# Altium schematic sheet 555-indicator-sheet.SchDoc(555-indicator-sheet)
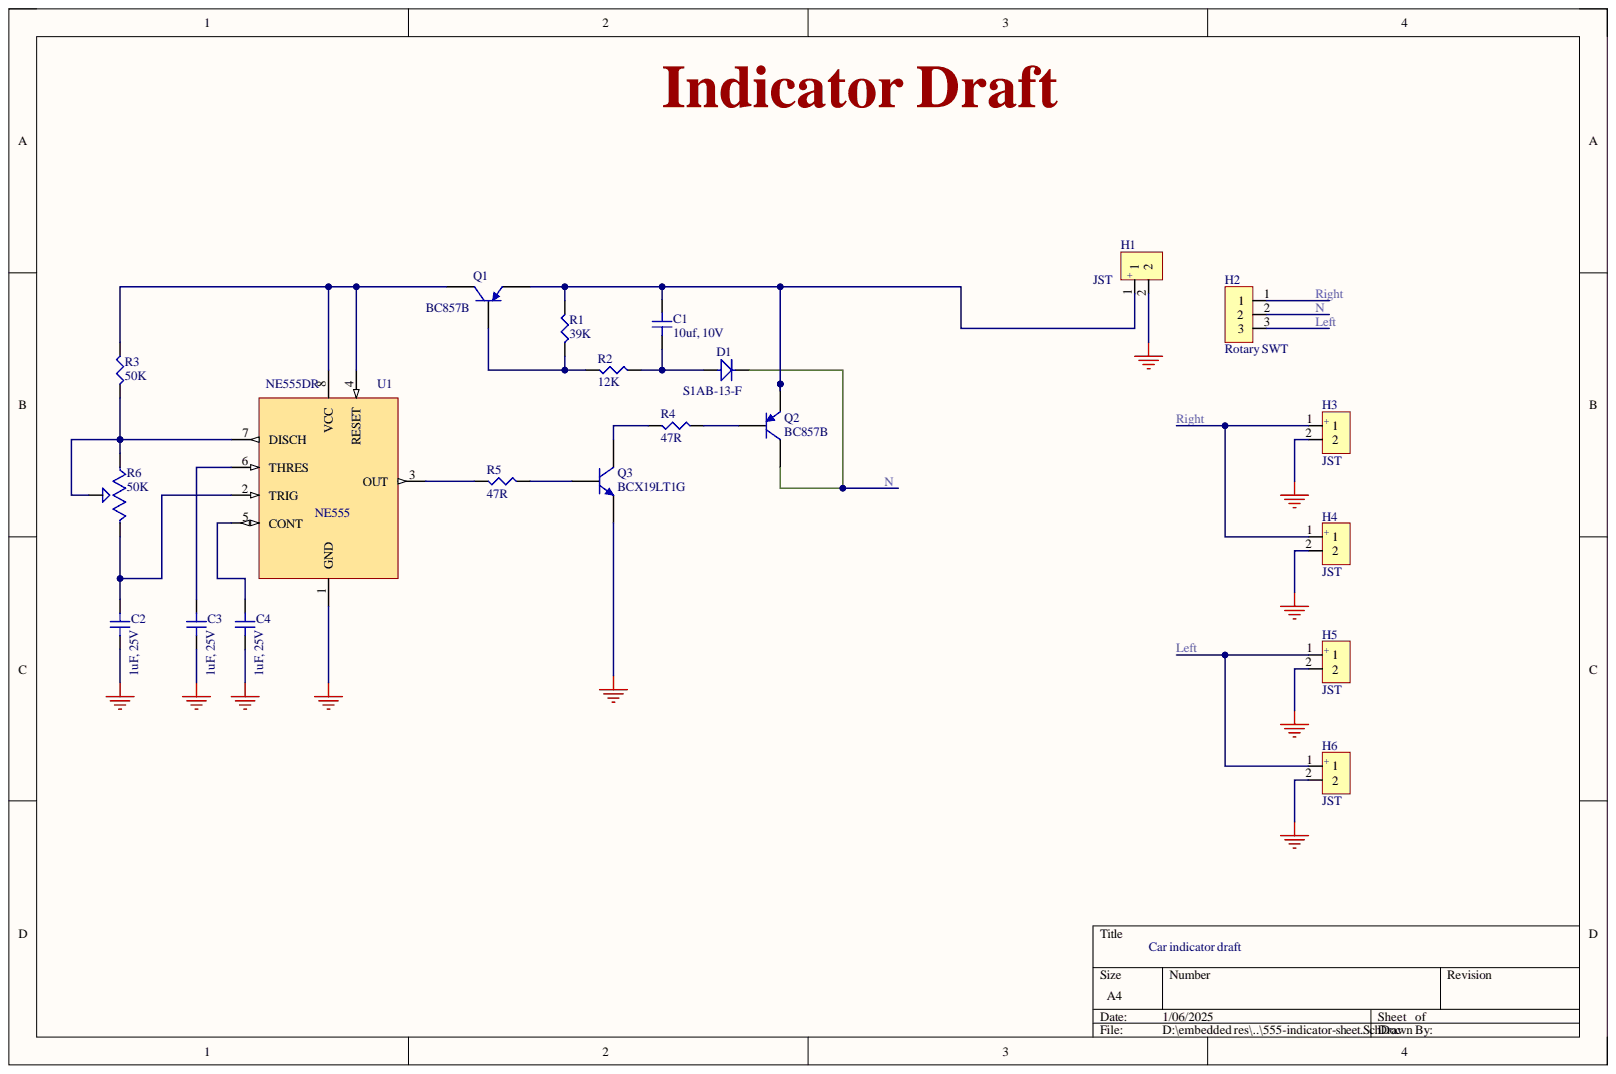
[diagram p0: 555-indicator-sheet.SchDoc]

## Parts
C1  10uf, 10V  [CAPC2012X140N]
C2  1uF, 25V  Rated DC Voltage (URdc): 25V  [CAPC2012X140N]
C3  1uF, 25V  Rated DC Voltage (URdc): 25V  [CAPC2012X140N]
C4  1uF, 25V  Rated DC Voltage (URdc): 25V  [CAPC2012X140N]
D1  S1AB-13-F  [FP-SMB-MFG]
H1  JST  [FP-B2B-XH-A_LF_SN-MFG]
H2  Rotary SWT  [JST_B3B-XH-A]
H3  JST  [FP-B2B-XH-A_LF_SN-MFG]
H4  JST  [FP-B2B-XH-A_LF_SN-MFG]
H5  JST  [FP-B2B-XH-A_LF_SN-MFG]
H6  JST  [FP-B2B-XH-A_LF_SN-MFG]
Q1  BC857B  [PNP_BC857B,235]
Q2  BC857B  [PNP_BC857B,235]
Q3  BCX19LT1G  [NPN_BCX19LT1G]
R1  39K  [RESC3116X65N]
R2  12K  [RESC3116X65N]
R3  50K  [RESC3116X65N]
R4  47R  [RESC3116X65N]
R5  47R  [RESC3116X65N]
R6  50K  [TRIM_3266W-1-503LF]
U1  NE555DR  [FP-D0008A-MFG]

## Nets
GND: C2.2 C3.2 C4.2 H1.2 H3.2 H4.2 H5.2 H6.2 Q3.2 U1.1(GND)
Left: H2.3 H5.1 H6.1
N: D1.2 H2.2 Q2.3
Right: H2.1 H3.1 H4.1
NetC1_1: C1.1 D1.1 R2.2
NetC1_2: C1.2 H1.1 Q1.2 Q2.2 R1.1
NetC2_1: C2.1 R6.3 U1.2(TRIG)
NetC3_1: C3.1 U1.6(THRES)
NetC4_1: C4.1 U1.5(CONT)
NetQ1_1: Q1.1 R1.2 R2.1
NetQ1_3: Q1.3 R3.1 U1.4(RESET) U1.8(VCC)
NetQ2_1: Q2.1 R4.2
NetQ3_1: Q3.1 R5.2
NetQ3_3: Q3.3 R4.1
NetR3_2: R3.2 R6.1 R6.2 U1.7(DISCH)
NetR5_1: R5.1 U1.3(OUT)

## Library data of the parts
C1 = CL21A106KPFNNNE: CAP CER 10UF 10V X5R 0805; Manufacturer: Samsung; Capacitor Type: Ceramic; Multilayer: Yes; Tolerance  (fill in as +-): 10%; Case/Package: 0805; Category: Ceramic Capacitors; Component Kind: Standard; ComponentLink1Description: https://datasheet.ciiva.com:443/pdfs/VipMasterIC/IC/SEMS/SEMS-S-A0008526420/SEMS-S-A0008526398-1.pdf?src-supplier=IHS+Markit; ComponentLink1URL: https://datasheet.ciiva.com:443/pdfs/VipMasterIC/IC/SEMS/SEMS-S-A0008526420/SEMS-S-A0008526398-1.pdf?src-supplier=IHS+Markit; ComponentLink2Description: https://datasheet.ciiva.com/16199/cl05b104kq5nnnc-16199024.pdf?src-supplier=Future+Electronics; ComponentLink2URL: https://datasheet.ciiva.com/16199/cl05b104kq5nnnc-16199024.pdf?src-supplier=Future+Electronics; ComponentLink3Description: https://datasheet.ciiva.com/15195/getdatasheetpartid-357423-15195857.pdf?src-supplier=Verical; ComponentLink3URL: https://datasheet.ciiva.com/15195/getdatasheetpartid-357423-15195857.pdf?src-supplier=Verical; ComponentLink4Description: https://datasheet.octopart.com/CL21A106KPFNNNE-Samsung-Electro-Mechanics-datasheet-136031801.pdf?src-supplier=Farnell; ComponentLink4URL: https://datasheet.octopart.com/CL21A106KPFNNNE-Samsung-Electro-Mechanics-datasheet-136031801.pdf?src-supplier=Farnell; ComponentLink5Description: https://datasheet.octopart.com/CL21A106KPFNNNE-Samsung-Electro-Mechanics-datasheet-8324245.pdf; ComponentLink5URL: https://datasheet.octopart.com/CL21A106KPFNNNE-Samsung-Electro-Mechanics-datasheet-8324245.pdf; ComponentLink6Description: https://datasheet.octopart.com/CL21A106KPFNNNE-Samsung-Electro-Mechanics-datasheet-97040617.pdf; ComponentLink6URL: https://datasheet.octopart.com/CL21A106KPFNNNE-Samsung-Electro-Mechanics-datasheet-97040617.pdf; ComponentLink7Description: https://datasheet.octopart.com/General-Multilayer-Ceramic-Capacitors-Samsung-datasheet-family-111.pdf; ComponentLink7URL: https://datasheet.octopart.com/General-Multilayer-Ceramic-Capacitors-Samsung-datasheet-family-111.pdf; ComponentLink8Description: https://datasheet.octopart.com/CL21A106KPFNNNE-Samsung-Electro-Mechanics-datasheet-110326887.pdf?src-supplier=Future+Electronics; ComponentLink8URL: https://datasheet.octopart.com/CL21A106KPFNNNE-Samsung-Electro-Mechanics-datasheet-110326887.pdf?src-supplier=Future+Electronics; ComponentLink9Description: https://datasheet.octopart.com/CL21A106KPFNNNE-Samsung-Electro-Mechanics-datasheet-81462124.pdf?src-supplier=Future+Electronics; ComponentLink9URL: https://datasheet.octopart.com/CL21A106KPFNNNE-Samsung-Electro-Mechanics-datasheet-81462124.pdf?src-supplier=Future+Electronics; ComponentLink10Description: https://datasheet.octopart.com/CL21A106KPFNNNE-Samsung-datasheet-48647544.pdf?src-supplier=Future+Electronics; ComponentLink10URL: https://datasheet.octopart.com/CL21A106KPFNNNE-Samsung-datasheet-48647544.pdf?src-supplier=Future+Electronics; ComponentLink11Description: https://datasheet.octopart.com/CL21A106KPFNNNE-Samsung-datasheet-26669229.pdf?src-supplier=Future+Electronics; ComponentLink11URL: https://datasheet.octopart.com/CL21A106KPFNNNE-Samsung-datasheet-26669229.pdf?src-supplier=Future+Electronics; ComponentLink12Description: https://datasheet.octopart.com/CL21A106KPFNNNE-Samsung-datasheet-22147434.pdf?src-supplier=TME; ComponentLink12URL: https://datasheet.octopart.com/CL21A106KPFNNNE-Samsung-datasheet-22147434.pdf?src-supplier=TME; ComponentLink13Description: https://datasheet.octopart.com/CL21A106KPFNNNE-Samsung-Electro-Mechanics-datasheet-11781485.pdf?src-supplier=Arrow+Electronics; ComponentLink13URL: https://datasheet.octopart.com/CL21A106KPFNNNE-Samsung-Electro-Mechanics-datasheet-11781485.pdf?src-supplier=Arrow+Electronics; Dielectric Material: Ceramic; Height: 1.35mm; JESD-609 Code: e3; LCSC part no: C17024; Length: 2mm; Manufacturer Part Number: CL21A106KPFNNNE; Max Operating Temperature: 85°C; Min Operating Temperature: -55°C; Mounting Technology: Surface Mount; Package Shape: Rectangular; Package Style: SMTMeter; Packaging: Tape and Reel; Pins: 2; Pricing: 1=0.1, 10=0.054, 50=0.0374, 100=0.0322, 500=0.02334, 1000=0.0206 (USD); Rated DC Voltage (URdc): 10V; Reach SVHC Compliant: Yes; RoHS Compliant: Yes; Stock: 539282; Supplier: Digikey; Supplier Part Number: 1276-1052-6-ND; Temperature Characteristics Code: X5R; Terminal Finish: Nickel; Termination: Wraparound; Tolerance (fill in as +-): 10%; Value: 10uF; Width: 1.25mm; Library Name: 555-indicator-Schlib.SchLib
C2-C4 = CL21B105KAFNNNE: CAP CER 1UF 25V X7R 0805; Manufacturer: Samsung; Capacitor Type: Ceramic; Multilayer: Yes; Tolerance  (fill in as +-): 10%; Case/Package: 0805; Category: Ceramic Capacitors; Component Kind: Standard; ComponentLink1Description: https://datasheet.ciiva.com:443/pdfs/VipMasterIC/IC/SEMS/SEMS-S-A0008523501/SEMS-S-A0008523563-1.pdf?src-supplier=IHS+Markit; ComponentLink1URL: https://datasheet.ciiva.com:443/pdfs/VipMasterIC/IC/SEMS/SEMS-S-A0008523501/SEMS-S-A0008523563-1.pdf?src-supplier=IHS+Markit; ComponentLink2Description: https://datasheet.ciiva.com/25800/cl21b105kafnnne-25800163.pdf?src-supplier=Digikey; ComponentLink2URL: https://datasheet.ciiva.com/25800/cl21b105kafnnne-25800163.pdf?src-supplier=Digikey; ComponentLink3Description: https://datasheet.ciiva.com/15195/getdatasheetpartid-355205-15195880.pdf?src-supplier=Verical; ComponentLink3URL: https://datasheet.ciiva.com/15195/getdatasheetpartid-355205-15195880.pdf?src-supplier=Verical; Dielectric Material: Ceramic; Height: 1.35mm; JESD-609 Code: e3; LCSC part no: C116352; Length: 2mm; Manufacturer Part Number: CL21B105KAFNNNE; Max Operating Temperature: 125°C; Min Operating Temperature: -55°C; Mounting Technology: Surface Mount; Package Shape: Rectangular; Package Style: SMTMeter; Packaging: Tape and Reel; Pins: 2; Pricing: 1=0.08, 10=0.027, 50=0.022, 100=0.0168, 500=0.0152, 1000=0.0136 (USD); Reach SVHC Compliant: Yes; RoHS: Yes; RoHS Compliant: Yes; Stock: 1459194; Supplier: Digikey; Supplier Part Number: 1276-1066-6-ND; Temperature Characteristics Code: X7R; Terminal Finish: Nickel; Termination: Wraparound; Tolerance (fill in as +-): 10%; Value: 1uF; Width: 1.25mm; Library Name: 555-indicator-Schlib.SchLib
D1 = S1AB-13-F: DIODE GEN PURP 50V 1A DO214AC; Manufacturer: Diodes; Additional Feature: HIGH RELIABILITY; Diode Element Material: SILICON; Diode Type: RECTIFIER; Forward Voltage: 1.1V; Non-rep Pk Forward Current-Max: 30A; Number of Elements: 1; Package Body Material: Plastic; Qualification Status: Not Qualified; Reverse Recovery Time-Max: 3us; Time @ Peak Reflow Temperature-Max (s): 40s; Category: Rectifier Diodes; Component Kind: Standard; ComponentLink1Description: https://datasheet.ciiva.com:443/pdfs/VipMasterIC/IC/DIOD/DIOD-S-A0007810797/DIOD-S-A0007810797-1.pdf?src-supplier=IHS+Markit; ComponentLink1URL: https://datasheet.ciiva.com:443/pdfs/VipMasterIC/IC/DIOD/DIOD-S-A0007810797/DIOD-S-A0007810797-1.pdf?src-supplier=IHS+Markit; JESD-30 Code: R-PDSO-C2; JESD-609 Code: e3; LCSC part no: C519094; Manufacturer Part Number: S1AB-13-F; Max Operating Temperature: 150°C; Max Output current: 1A; Min Operating Temperature: -65°C; Moisture Sensitivity Level: 1; Package Shape: Rectangular; Package Style: SMALL OUTLINEMeter; Peak Reflow Temperature (Cel): 260°C; Pins: 2; Pricing: 1=0.18, 10=0.121, 100=0.0813, 500=0.06208, 1000=0.05559 (USD); Reach SVHC Compliant: No; Rep Pk Reverse Voltage-Max: 50V; RoHS: Yes; RoHS Compliant: Yes; Stock: 182225; Supplier: Digikey; Supplier Part Number: S1AB-FDICT-ND; Surface Mount: Yes; Terminal Finish: Tin; Terminal Form: C BEND; Terminal Position: DUAL; Library Name: 555-indicator-Schlib.SchLib
H1 H3-H6 = B2B-XH-A(LF)(SN): CONN HEADER VERT 2POS 2.5MM; Category: Headers and Wire Housings; Component Kind: Standard; ComponentLink1Description: https://datasheet.ciiva.com/11746/0900766b8082653e-11746979.pdf?src-supplier=Rs; ComponentLink1URL: https://datasheet.ciiva.com/11746/0900766b8082653e-11746979.pdf?src-supplier=Rs; ComponentLink2Description: https://datasheet.ciiva.com/26894/getdatasheetpartid-640357-26894434.pdf?src-supplier=Verical; ComponentLink2URL: https://datasheet.ciiva.com/26894/getdatasheetpartid-640357-26894434.pdf?src-supplier=Verical; ComponentLink3Description: https://datasheet.ciiva.com/26894/getdatasheetpartid-385291-26894760.pdf?src-supplier=Verical; ComponentLink3URL: https://datasheet.ciiva.com/26894/getdatasheetpartid-385291-26894760.pdf?src-supplier=Verical; ComponentLink4Description: https://datasheet.ciiva.com/8052/5448-8052024.pdf?src-supplier=Element14; ComponentLink4URL: https://datasheet.ciiva.com/8052/5448-8052024.pdf?src-supplier=Element14; LCSC part no: C158012; Manufacturer: JST; Manufacturer Part Number: B2B-XH-A(LF)(SN); Pricing: 1=0.0563, 750=0.0541, 2500=0.0518, 7500=0.0498, 25000=0.0458 (USD); RoHS: Yes; Stock: 668373; Supplier: Future Electronics; Supplier Part Number: 1638840; Library Name: 555-indicator-Schlib.SchLib
H2 = B3B-XH-A(LF)(SN): CONN HEADER VERT 3POS 2.5MM; Manufacturer: JST; Category: Headers and Wire Housings; Component Kind: Standard; ComponentLink1Description: https://datasheet.ciiva.com/11746/0900766b8082653f-11746520.pdf?src-supplier=Rs; ComponentLink1URL: https://datasheet.ciiva.com/11746/0900766b8082653f-11746520.pdf?src-supplier=Rs; ComponentLink2Description: https://datasheet.ciiva.com/26894/getdatasheetpartid-640434-26894454.pdf?src-supplier=Verical; ComponentLink2URL: https://datasheet.ciiva.com/26894/getdatasheetpartid-640434-26894454.pdf?src-supplier=Verical; ComponentLink3Description: https://datasheet.ciiva.com/26894/getdatasheetpartid-440399-26894778.pdf?src-supplier=Verical; ComponentLink3URL: https://datasheet.ciiva.com/26894/getdatasheetpartid-440399-26894778.pdf?src-supplier=Verical; LCSC part no: C144394; Manufacturer Part Number: B3B-XH-A(LF)(SN); Pricing: 1=0.0792, 500=0.0757, 1500=0.0727, 5000=0.0695, 15000=0.0637 (USD); RoHS: Yes; Stock: 57931; Supplier: Future Electronics; Supplier Part Number: 8262735; Library Name: 555-indicator-Schlib.SchLib
Q1 Q2 = BC857B: BJT SOT-23 45V 100MA; Manufacturer: Infineon; Component Kind: Standard; LCSC part no: C181146; Manufacturer Part Number: BC857B; Pricing: 1=0.23, 10=0.14, 100=0.0872, 500=0.06382, 1000=0.05625 (USD); RoHS: Yes; Stock: 18586; Supplier: Digikey; Supplier Part Number: BC857BE6327HTSA1CT-ND; Library Name: 555-indicator-Schlib.SchLib
Q3 = BCX19LT1G: TRANS NPN 45V 0.5A SOT23-3; Manufacturer: ON Semiconductor; DC Current Gain-Min (hFE): 40; JEDEC-95 Code: TO-236AB; Max Collector Current: 500mA; Number of Elements: 1; Package Body Material: Plastic; Power Dissipation-Max (Abs): 225mW; Qualification Status: Not Qualified; Time @ Peak Reflow Temperature-Max (s): 40s; Transistor Element Material: SILICON; Category: BJTs; Collector-emitter Voltage-Max: 45V; Component Kind: Standard; ComponentLink1Description: https://datasheet.ciiva.com:443/pdfs/VipMasterIC/IC/ONSM/ONSMS38866/ONSMS38866-1.pdf?src-supplier=IHS+Markit; ComponentLink1URL: https://datasheet.ciiva.com:443/pdfs/VipMasterIC/IC/ONSM/ONSMS38866/ONSMS38866-1.pdf?src-supplier=IHS+Markit; ComponentLink2Description: https://datasheet.ciiva.com/15138/getdatasheetpartid-38785-15138642.pdf?src-supplier=Verical; ComponentLink2URL: https://datasheet.ciiva.com/15138/getdatasheetpartid-38785-15138642.pdf?src-supplier=Verical; ComponentLink3Description: https://datasheet.ciiva.com/976/bcx17lt1-d-976538.pdf?src-supplier=Digikey; ComponentLink3URL: https://datasheet.ciiva.com/976/bcx17lt1-d-976538.pdf?src-supplier=Digikey; ComponentLink4Description: https://datasheet.ciiva.com/976/bcx17lt1-d-976539.pdf?src-supplier=Digikey; ComponentLink4URL: https://datasheet.ciiva.com/976/bcx17lt1-d-976539.pdf?src-supplier=Digikey; ComponentLink5Description: https://datasheet.ciiva.com/976/bcx17lt1-d-976540.pdf?src-supplier=Digikey; ComponentLink5URL: https://datasheet.ciiva.com/976/bcx17lt1-d-976540.pdf?src-supplier=Digikey; ComponentLink6Description: https://datasheet.ciiva.com/11204/bcx17lt1-d-11204428.pdf?src-supplier=Avnet; ComponentLink6URL: https://datasheet.ciiva.com/11204/bcx17lt1-d-11204428.pdf?src-supplier=Avnet; JESD-30 Code: R-PDSO-G3; JESD-609 Code: e3; LCSC part no: C232541; Manufacturer Part Number: BCX19LT1G; Max Operating Temperature: 150°C; Moisture Sensitivity Level: 1; Package Shape: Rectangular; Package Style: SMALL OUTLINEMeter; Peak Reflow Temperature (Cel): 260°C; Pins: 3; Polarity/Channel Type: NPN; Pricing: 1=0.12, 10=0.085, 100=0.0569, 500=0.04318, 1000=0.03851 (USD); Reach SVHC Compliant: Yes; RoHS: Yes; RoHS Compliant: Yes; Stock: 4512; Supplier: Digikey; Supplier Part Number: BCX19LT1GOSCT-ND; Surface Mount: Yes; Terminal Finish: Tin; Terminal Form: Gull Wing; Terminal Position: DUAL; Library Name: 555-indicator-Schlib.SchLib
R1 = ERJ-PB6B3902V: Res SMD 39K Ohm 0.1% 1/4W 0805; Manufacturer: Panasonic; Category: Chip SMD Resistors; Component Kind: Standard; ComponentLink1Description: http://datasheet.octopart.com/ERJPB6B3902V-Panasonic-datasheet-82522998.pdf?src-supplier=Farnell; ComponentLink1URL: http://datasheet.octopart.com/ERJPB6B3902V-Panasonic-datasheet-82522998.pdf?src-supplier=Farnell; ComponentLink2Description: https://datasheet.octopart.com/ERJ-PB6B3902V-Panasonic-datasheet-82522998.pdf?src-supplier=Newark; ComponentLink2URL: https://datasheet.octopart.com/ERJ-PB6B3902V-Panasonic-datasheet-82522998.pdf?src-supplier=Newark; ComponentLink3Description: http://datasheet.octopart.com/ERJPB6B3902V-Panasonic-datasheet-82522998.pdf?src-supplier=Verical; ComponentLink3URL: http://datasheet.octopart.com/ERJPB6B3902V-Panasonic-datasheet-82522998.pdf?src-supplier=Verical; ComponentLink4Description: https://industrial.panasonic.com/cdbs/www-data/pdf/RDM0000/AOA0000C328.pdf?src-supplier=Digikey; ComponentLink4URL: https://industrial.panasonic.com/cdbs/www-data/pdf/RDM0000/AOA0000C328.pdf?src-supplier=Digikey; LCSC part no: C4158317; Manufacturer Part Number: ERJ-PB6B3902V; Pricing: 1=0.29, 10=0.161, 25=0.1292, 50=0.1098, 100=0.0939, 250=0.07684, 500=0.06644, 1000=0.05775 (USD); RoHS: Yes; Stock: 9918; Supplier: Digikey; Supplier Part Number: P20774CT-ND; Library Name: 555-indicator-Schlib.SchLib
R2 = ERJPB6B1202V: RES SMD 12K OHM 0.1% 1/4W 0805; Manufacturer: Panasonic; Package Height: 0.6mm; Package Length: 2mm; Package Width: 1.25mm; Power: 250mW; Rated Temperature: 70°C; Reference Standard: AEC-Q200; Temperature Coefficient: 50ppm/°C; Value: 12kR; Working Voltage: 150V; Case/Package: 0805; Category: Chip SMD Resistors; Component Kind: Standard; ComponentLink1Description: https://datasheet.ciiva.com:443/pdfs/VipMasterIC/IC/PANA/PANA-S-A0009891633/PANA-S-A0009891633-1.pdf?src-supplier=IHS+Markit; ComponentLink1URL: https://datasheet.ciiva.com:443/pdfs/VipMasterIC/IC/PANA/PANA-S-A0009891633/PANA-S-A0009891633-1.pdf?src-supplier=IHS+Markit; ComponentLink2Description: https://datasheet.octopart.com/ERJPB6B1202V-Panasonic-datasheet-82522998.pdf?src-supplier=Newark; ComponentLink2URL: https://datasheet.octopart.com/ERJPB6B1202V-Panasonic-datasheet-82522998.pdf?src-supplier=Newark; ComponentLink3Description: https://industrial.panasonic.com/cdbs/www-data/pdf/RDM0000/AOA0000C328.pdf?src-supplier=Digikey; ComponentLink3URL: https://industrial.panasonic.com/cdbs/www-data/pdf/RDM0000/AOA0000C328.pdf?src-supplier=Digikey; ComponentLink4Description: https://datasheet.octopart.com/ERJPB6B1202V-Panasonic-datasheet-136610817.pdf?src-supplier=Newark; ComponentLink4URL: https://datasheet.octopart.com/ERJPB6B1202V-Panasonic-datasheet-136610817.pdf?src-supplier=Newark; ComponentLink5Description: https://datasheet.octopart.com/ERJPB6B1202V-Panasonic-datasheet-177212511.pdf?src-supplier=Burklin+Elektronik; ComponentLink5URL: https://datasheet.octopart.com/ERJPB6B1202V-Panasonic-datasheet-177212511.pdf?src-supplier=Burklin+Elektronik; Construction: Rectangular; LCSC part no: C413717; Manufacturer Part Number: ERJPB6B1202V; Max Operating Temperature: 155°C; Min Operating Temperature: -55°C; Mounting Technology: Surface Mount; Packaging: Tape and Reel; Pins: 2; Pricing: 1=0.29, 10=0.161, 25=0.1292, 50=0.1098, 100=0.0939, 250=0.07684, 500=0.06644, 1000=0.05775 (USD); Reach SVHC Compliant: Yes; Resistor Type: FIXED RESISTOR; RoHS Compliant: Yes; Stock: 15534; Supplier: Digikey; Supplier Part Number: P20716CT-ND; Technology: METAL GLAZE/THICK FILM; Termination: Wraparound; Tolerance: 0.1%; Library Name: 555-indicator-Schlib.SchLib
R3 = RT1206BRD0750KL: RES SMD 50K OHM 0.1% 1/4W 1206; Manufacturer: Yageo; Additional Feature: LASER TRIMMABLE; Power: 250mW; Rated Temperature: 70°C; Temperature Coefficient: 25ppm/°C; Value: 50kR; Working Voltage: 200V; Case/Package: 1206; Category: Chip SMD Resistors; Component Kind: Standard; ComponentLink1Description: https://datasheet.ciiva.com:443/pdfs/VipMasterIC/IC/YAGO/YAGO-S-A0003507815/YAGO-S-A0003507815-1.pdf?src-supplier=IHS+Markit; ComponentLink1URL: https://datasheet.ciiva.com:443/pdfs/VipMasterIC/IC/YAGO/YAGO-S-A0003507815/YAGO-S-A0003507815-1.pdf?src-supplier=IHS+Markit; ComponentLink2Description: https://datasheet.octopart.com/RT1206BRD0750KL-Yageo-datasheet-166250672.pdf?src-supplier=Future+Electronics; ComponentLink2URL: https://datasheet.octopart.com/RT1206BRD0750KL-Yageo-datasheet-166250672.pdf?src-supplier=Future+Electronics; ComponentLink3Description: http://www.yageo.com/documents/recent/PYu-RT_1-to-0.01_RoHS_L_8.pdf?src-supplier=Digikey; ComponentLink3URL: http://www.yageo.com/documents/recent/PYu-RT_1-to-0.01_RoHS_L_8.pdf?src-supplier=Digikey; LCSC part no: C706417; Manufacturer Part Number: RT1206BRD0750KL; Max Operating Temperature: 155°C; Min Operating Temperature: -55°C; Mounting Technology: Surface Mount; Package Shape: Rectangular; Packaging: Tape and Reel; Pins: 2; Pricing: 1=0.34, 10=0.246, 50=0.1908, 100=0.1693, 500=0.1275, 1000=0.1122 (USD); Reach SVHC Compliant: Yes; Resistor Type: FIXED RESISTOR; RoHS: Yes; RoHS Compliant: Yes; Stock: 21777; Supplier: Digikey; Supplier Part Number: 13-RT1206BRD0750KLCT-ND; Technology: THIN FILM; Termination: Wraparound; Tolerance: 0.1%; Library Name: 555-indicator-Schlib.SchLib
R4 R5 = RPC0805JT47R0: RES 47 OHM 5% 1/4W 0805; LCS part no: C5869749; Manufacturer: Stackpole Electronics; Package Height: 0.5mm; Package Length: 2mm; Package Width: 1.25mm; Power: 250mW; Rated Temperature: 70°C; Reference Standard: AEC-Q200; Temperature Coefficient: 200ppm/°C; Working Voltage: 150V; Case/Package: 0805; Category: Chip SMD Resistors; Component Kind: Standard; ComponentLink1Description: https://datasheet.ciiva.com:443/pdfs/VipMasterIC/IC/SEIE/SEIE-S-A0010122904/SEIE-S-A0010122904-1.pdf?src-supplier=IHS+Markit; ComponentLink1URL: https://datasheet.ciiva.com:443/pdfs/VipMasterIC/IC/SEIE/SEIE-S-A0010122904/SEIE-S-A0010122904-1.pdf?src-supplier=IHS+Markit; ComponentLink2Description: https://www.seielect.com/Catalog/SEI-rpc.pdf?src-supplier=Digikey; ComponentLink2URL: https://www.seielect.com/Catalog/SEI-rpc.pdf?src-supplier=Digikey; ComponentLink3Description: https://www.seielect.com/catalog/SEI-Packaging.pdf?src-supplier=Digikey; ComponentLink3URL: https://www.seielect.com/catalog/SEI-Packaging.pdf?src-supplier=Digikey; Construction: Rectangular; JESD-609 Code: e3; LCSC part no: C5869749; Manufacturer Part Number: RPC0805JT47R0; Max Operating Temperature: 155°C; Min Operating Temperature: -55°C; Mounting Technology: Surface Mount; Package Shape: Rectangular; Packaging: Tape and Reel; Pins: 2; Pricing: 1=0.17, 10=0.087, 50=0.058, 100=0.0494, 500=0.03554, 1000=0.03137 (USD); Reach SVHC Compliant: Yes; Resistor Type: FIXED RESISTOR; RoHS: Yes; RoHS Compliant: Yes; Stock: 55267; Supplier: Digikey; Supplier Part Number: RPC0805JT47R0DKR-ND; Technology: METAL GLAZE/THICK FILM; Terminal Finish: Nickel; Termination: Wraparound; Tolerance: 5%; Value: 47R; Library Name: 555-indicator-Schlib.SchLib
R6 = 3266W-1-503LF (2): 50K OHM 0.25W PC PIN TOP; Manufacturer: Bourns; Actuator Orientation: Vertical; Actuator Type: SCREW; Lead Diameter: 0.46mm; Lead Length: 4.88mm; Number of Turns: 12; Package Height: 6.85mm; Package Length: 6.71mm; Package Width: 4.5mm; Power: 250mW; Rated Temperature: 70°C; Resistance Law: Linear; Rotational Angle: 4320°; Temperature Coefficient: -100100ppm/°C; Tolerance: 10%; Value: 50kR; Case/Package: 2618; Category: Variable Resistors and Potentiometers; Component Kind: Standard; ComponentLink1Description: https://datasheet.ciiva.com:443/pdfs/VipMasterIC/IC/BOUR/BOUR-S-A0008901203/BOUR-S-A0008901203-1.pdf?src-supplier=IHS+Markit; ComponentLink1URL: https://datasheet.ciiva.com:443/pdfs/VipMasterIC/IC/BOUR/BOUR-S-A0008901203/BOUR-S-A0008901203-1.pdf?src-supplier=IHS+Markit; ComponentLink2Description: https://datasheet.ciiva.com/29122/447254-da-01-en-trimmer-tht-3266w-1-503lf-50k-0-25w-29122338.pdf?src-supplier=Conrad; ComponentLink2URL: https://datasheet.ciiva.com/29122/447254-da-01-en-trimmer-tht-3266w-1-503lf-50k-0-25w-29122338.pdf?src-supplier=Conrad; ComponentLink3Description: https://datasheet.ciiva.com/2156/3266-2156991.pdf?src-supplier=Digikey; ComponentLink3URL: https://datasheet.ciiva.com/2156/3266-2156991.pdf?src-supplier=Digikey; Construction: Trimmer Pot; JESD-609 Code: e3; LCSC part no: C780226; Manufacturer Part Number: 3266W-1-503LF; Max Operating Temperature: 150°C; Min Operating Temperature: -55°C; Mounting Technology: Through Hole; Package Shape: Rectangular; Packaging: Rail/Tube; Pins: 3; Pricing: 1=4.17, 10=3.35, 25=3.0676, 50=2.869, 100=2.6824, 250=2.45264, 500=2.29082, 1000=2.13862, 5000=1.81904 (USD); Reach SVHC Compliant: No; Resistor Type: TRIMMER; RoHS: Yes; RoHS Compliant: Yes; Stock: 1328; Supplier: Digikey; Supplier Part Number: 3266W-503LF-ND; Technology: CERMET; Terminal Finish: Tin; Termination: Wire; Library Name: 555-indicator-Schlib.SchLib
U1 = NE555DR: IC OSC SGL TIMER 100KHZ 8-SOIC; Manufacturer: Texas Instruments; Channels: 1; Max Supply Voltage: 16V; Min Supply Voltage: 4.5V; Nominal Supply Voltage: 5V; Package Body Material: Plastic; Package Equivalence Code: SOP8,.25; Power Supplies: 5/15V; Qualification Status: Not Qualified; Throw Configuration: PULSE; RECTANGULAR; Case/Package: SOP; Category: Timers and Oscillators; Component Kind: Standard; ComponentLink1Description: https://www.ti.com:443/lit/ds/symlink/na555.pdf?src-supplier=IHS+Markit; ComponentLink1URL: https://www.ti.com:443/lit/ds/symlink/na555.pdf?src-supplier=IHS+Markit; ComponentLink2Description: https://datasheet.ciiva.com/1233/ne555-1233132.pdf?src-supplier=Digikey; ComponentLink2URL: https://datasheet.ciiva.com/1233/ne555-1233132.pdf?src-supplier=Digikey; ComponentLink3Description: https://datasheet.ciiva.com/1233/ne555-1233131.pdf?src-supplier=Digikey; ComponentLink3URL: https://datasheet.ciiva.com/1233/ne555-1233131.pdf?src-supplier=Digikey; ComponentLink4Description: https://datasheet.ciiva.com/1139/1874740-1139901.pdf?src-supplier=Element14; ComponentLink4URL: https://datasheet.ciiva.com/1139/1874740-1139901.pdf?src-supplier=Element14; ComponentLink5Description: https://datasheet.ciiva.com/1233/ne555-1233133.pdf?src-supplier=Digikey; ComponentLink5URL: https://datasheet.ciiva.com/1233/ne555-1233133.pdf?src-supplier=Digikey; ComponentLink6Description: https://datasheet.ciiva.com/46395/1874740-46395978.pdf?src-supplier=Element14; ComponentLink6URL: https://datasheet.ciiva.com/46395/1874740-46395978.pdf?src-supplier=Element14; Height - Seated (Max): 1.75mm; JESD-30 Code: R-PDSO-G8; JESD-609 Code: e3; LCSC part no: C7593; Length: 4.9mm; Manufacturer Part Number: NE555DR; Max Operating Temperature: 70°C; Min Operating Temperature: 0°C; Moisture Sensitivity Level: 1; Package Shape: Rectangular; Package Style: SMALL OUTLINEMeter; Peak Reflow Temperature (Cel): 260°C; Pins: 8; Pricing: 1=0.2, 10=0.136, 25=0.1196, 100=0.1018, 250=0.0934, 500=0.08832, 1000=0.08413 (USD); Reach SVHC Compliant: Yes; RoHS: Yes; RoHS Compliant: Yes; Stock: 55657; Supplier: Digikey; Supplier Part Number: 296-6501-1-ND; Surface Mount: Yes; Technology: BIPOLAR; Temperature Grade: COMMERCIAL; Terminal Finish: Tin; Terminal Form: Gull Wing; Terminal Pitch: 1.27mm; Terminal Position: DUAL; Width: 3.9mm; Library Name: 555-indicator-Schlib.SchLib
